# Altium schematic sheet pca10036_sheet1_radio.SchDoc
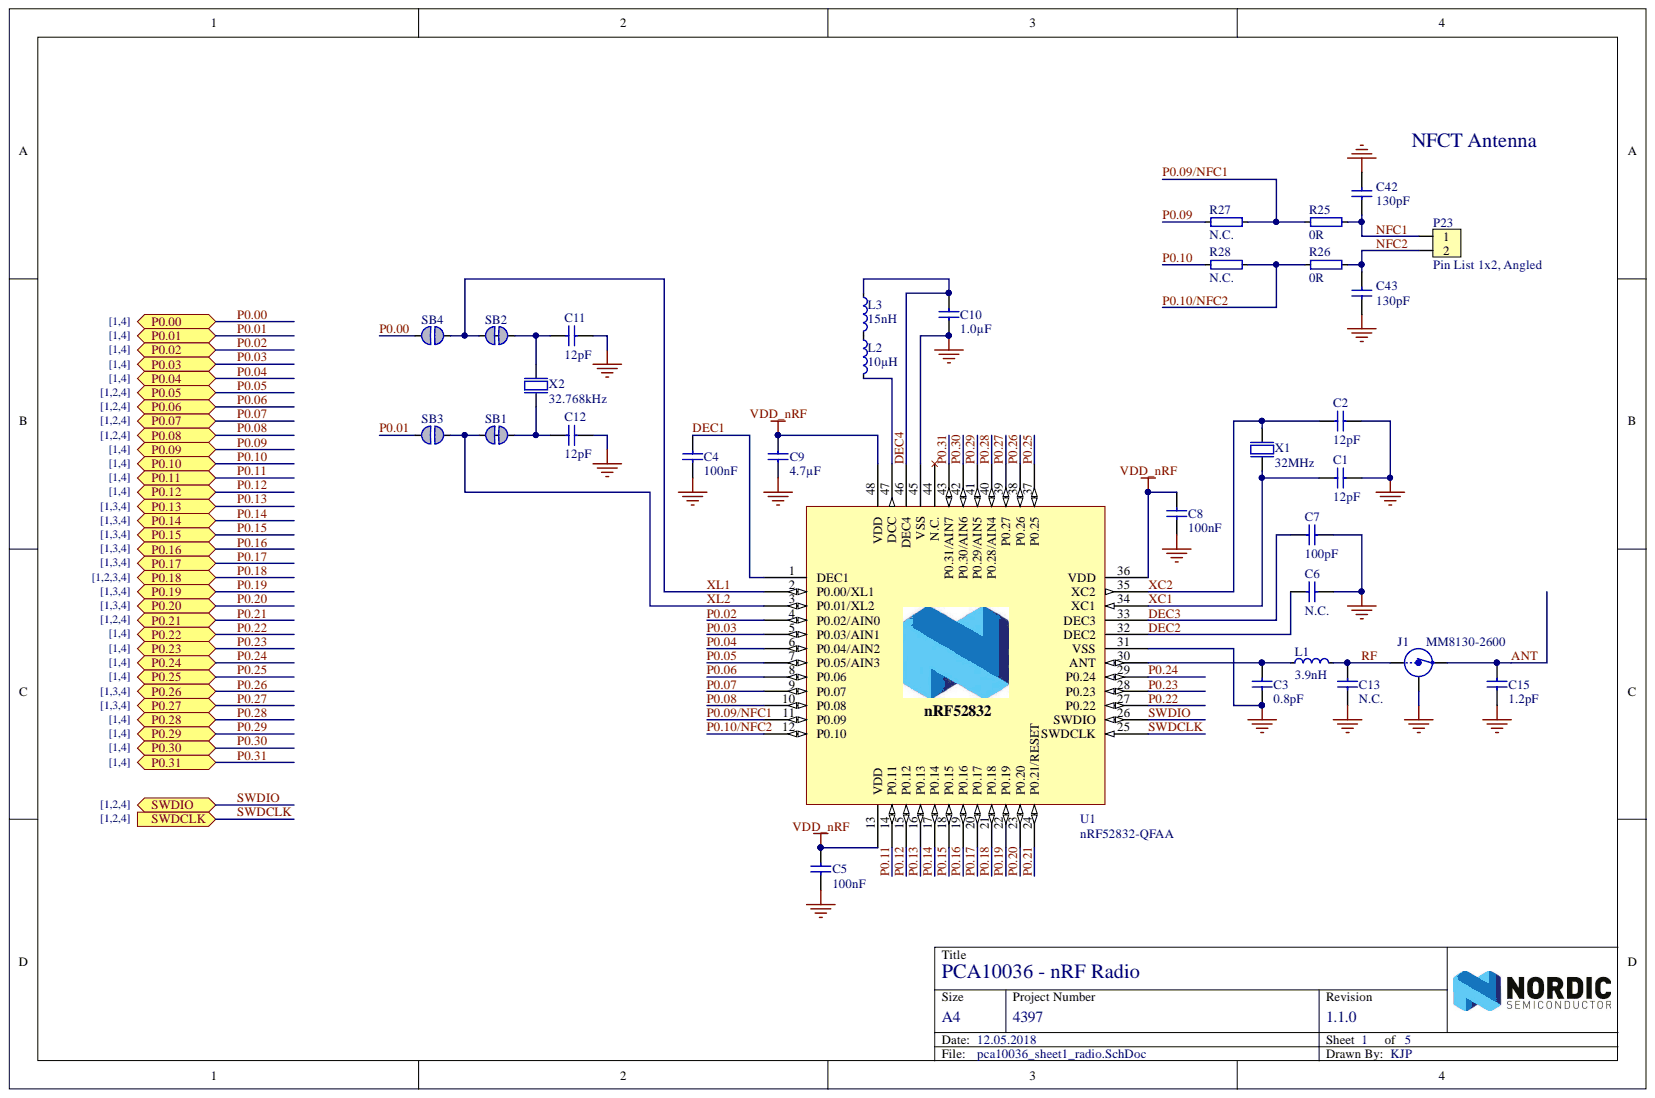
[diagram p0: pca10036_sheet1_radio.SchDoc]

## Parts
C1  12pF  [CAPC1005X04L]
C2  12pF  [CAPC1005X04L]
C3  0.8pF  [CAPC1005X04L]
C4  100nF  [CAPC1005X04L]
C5  100nF  [CAPC1005X04L]
C6  N.C.  [CAPC1005X04L]
C7  100pF  [CAPC1005X04L]
C8  100nF  [CAPC1005X04L]
C9  4.7µF  [CAPC1608X06L]
C10  1.0µF  [CAPC1608X06L]
C11  12pF  [CAPC1005X04L]
C12  12pF  [CAPC1005X04L]
C13  N.C.  [CAPC1005X04L]
C15  1.2pF  [CAPC1005X04L]
C42  130pF  [CAPC1005X04L]
C43  130pF  [CAPC1005X04L]
J1  MM8130-2600  [COAXIAL-SWF]
L1  3.9nH  [INDC1005X04L]
L2  10µH  [INDC1608X06L]
L3  15nH  [INDC1005X04L]
P23  Pin List 1x2, Angled  [LIST_ANG_1x2-SMD-2.00MM]
R25  0R  [RESC1005X04L]
R26  0R  [RESC1005X04L]
R27  N.C.  [RESC1005X04L]
R28  N.C.  [RESC1005X04L]
SB1  Solderbridge  [Solderbridge_Shorted]  (value not drawn)
SB2  Solderbridge  [Solderbridge_Shorted]  (value not drawn)
SB3  Solderbridge  [Solderbridge]  (value not drawn)  (no BOM)
SB4  Solderbridge  [Solderbridge]  (value not drawn)  (no BOM)
U1  nRF52832-QFAA  [QFN40P600X600X90-48N]
X1  32MHz  [BT-XTAL_2016]
X2  32.768kHz  [XTAL_3215]

## Ports
P0.00, P0.01, P0.02, P0.03, P0.04, P0.05, P0.06, P0.07, P0.08, P0.09, P0.10, P0.11, P0.12, P0.13, P0.14, P0.15, P0.16, P0.17, P0.18, P0.19, P0.20, P0.21, P0.22, P0.23, P0.24, P0.25, P0.26, P0.27, P0.28, P0.29, P0.30, P0.31, SWDCLK, SWDIO

## Nets
GND: C1.2 C2.2 C3.1 C4.2 C5.2 C6.2 C7.2 C8.2 C9.2 C10.1 C11.1 C12.1 C13.2 C15.2 C42.2 C43.1 J1.2 U1.31(VSS) U1.45(VSS) U1.49 X1.2 X1.4
VDD_nRF: C5.1 C8.1 C9.1 U1.13(VDD) U1.36(VDD) U1.48(VDD)
ANT: C15.1 J1.3
DEC1: C4.1 U1.1(DEC1)
DEC2: C6.1 U1.32(DEC2)
DEC3: C7.1 U1.33(DEC3)
DEC4: C10.2 L3.1 U1.46(DEC4)
NFC1: C42.1 P23.1 R25.1
NFC2: C43.2 P23.2 R26.1
P0.00: SB4.2 ; port P0.00
P0.01: SB3.2 ; port P0.01
P0.02: U1.4(P0.02/AIN0) ; port P0.02
P0.03: U1.5(P0.03/AIN1) ; port P0.03
P0.04: U1.6(P0.04/AIN2) ; port P0.04
P0.05: U1.7(P0.05/AIN3) ; port P0.05
P0.06: U1.8(P0.06) ; port P0.06
P0.07: U1.9(P0.07) ; port P0.07
P0.08: U1.10(P0.08) ; port P0.08
P0.09: R27.1 ; port P0.09
P0.09/NFC1: R25.2 R27.2 U1.11(P0.09)
P0.10: R28.1 ; port P0.10
P0.10/NFC2: R26.2 R28.2 U1.12(P0.10)
P0.11: U1.14(P0.11) ; port P0.11
P0.12: U1.15(P0.12) ; port P0.12
P0.13: U1.16(P0.13) ; port P0.13
P0.14: U1.17(P0.14) ; port P0.14
P0.15: U1.18(P0.15) ; port P0.15
P0.16: U1.19(P0.16) ; port P0.16
P0.17: U1.20(P0.17) ; port P0.17
P0.18: U1.21(P0.18) ; port P0.18
P0.19: U1.22(P0.19) ; port P0.19
P0.20: U1.23(P0.20) ; port P0.20
P0.21: U1.24(P0.21/RESET) ; port P0.21
P0.22: U1.27(P0.22) ; port P0.22
P0.23: U1.28(P0.23) ; port P0.23
P0.24: U1.29(P0.24) ; port P0.24
P0.25: U1.37(P0.25) ; port P0.25
P0.26: U1.38(P0.26) ; port P0.26
P0.27: U1.39(P0.27) ; port P0.27
P0.28: U1.40(P0.28/AIN4) ; port P0.28
P0.29: U1.41(P0.29/AIN5) ; port P0.29
P0.30: U1.42(P0.30/AIN6) ; port P0.30
P0.31: U1.43(P0.31/AIN7) ; port P0.31
RF: C13.1 J1.1 L1.2
SWDCLK: U1.25(SWDCLK) ; port SWDCLK
SWDIO: U1.26(SWDIO) ; port SWDIO
XC1: C1.1 U1.34(XC1) X1.1
XC2: C2.1 U1.35(XC2) X1.3
XL1: SB2.2 SB4.1 U1.2(P0.00/XL1)
XL2: SB1.2 SB3.1 U1.3(P0.01/XL2)
NetC3_2: C3.2 L1.1 U1.30(ANT)
NetC11_2: C11.2 SB2.1 X2.1
NetC12_2: C12.2 SB1.1 X2.2
NetL2_1: L2.1 L3.2
NetL2_2: L2.2 U1.47(DCC)

Not connected: U1 44(N.C.)

## Library data of the parts
C1 C2 C11 C12 = C0402-12p: Capacitor, NP0, ±2%; Artikkelnr Noca: 20029159; Artikkelnr Simpro: N.A.; Database Table Name: Capacitors$; Note: 50V; Component Kind: Standard; Footprint Doc: 0402; LatestRevisionDate: 2011-05-11; Library Name: Nordic Passive DB.DbLib; Part Number: C0402-12p; Pin Count: 2; Published: 2009-05-08; Value: 12pF; Publisher: Nordic Semiconductor
C3 = C0402-0.8p: Capacitor, NP0, ±5%; Artikkelnr Noca: 20029147; Artikkelnr Simpro: N.A.; Database Table Name: Capacitors$; Note: 50V; Component Kind: Standard; Footprint Doc: 0402; LatestRevisionDate: 2012-11-21; Library Name: Nordic Passive DB.DbLib; Part Number: C0402-0.8p; Pin Count: 2; Published: 2009-05-08; Value: 0.8pF; Publisher: Nordic Semiconductor
C4 C5 C8 = C0402-100n: Capacitor, X7R, ±10%; Artikkelnr Noca: 20039200; Artikkelnr Simpro: N.A.; Database Table Name: Capacitors$; Note: 16V; Component Kind: Standard; Footprint Doc: 0402; LatestRevisionDate: 2009-11-23; Library Name: Nordic Passive DB.DbLib; Part Number: C0402-100n; Pin Count: 2; Published: 2009-05-08; Value: 100nF; Publisher: Nordic Semiconductor
C6 C13 = C0402-N.C.: Not mounted; Artikkelnr Noca: N.A.; Database Table Name: Capacitors$; Note: Chip Cap; Artikkelnr Simpro: N.A.; Component Kind: Standard; Footprint Doc: 0402; LatestRevisionDate: 2009-05-08; Library Name: Nordic Passive DB.DbLib; Part Number: C0402-N.C.; Pin Count: 2; Published: 2009-05-08; Value: N.C.; Publisher: Nordic Semiconductor
C7 = C0402-100p: Capacitor, NP0, ±5%; Artikkelnr Noca: 20029135; Artikkelnr Simpro: N.A.; Database Table Name: Capacitors$; Note: 50V; Component Kind: Standard; Footprint Doc: 0402; LatestRevisionDate: 2009-05-08; Library Name: Nordic Passive DB.DbLib; Part Number: C0402-100p; Pin Count: 2; Published: 2009-05-08; Value: 100pF; Publisher: Nordic Semiconductor
C9 = C0603-4µ7: Capacitor, X5R, ±10%; Artikkelnr Noca: 20069060; Artikkelnr Simpro: N.A.; Database Table Name: Capacitors$; Note: 6.3V; Component Kind: Standard; Footprint Doc: 0603; LatestRevisionDate: 2009-11-23; Library Name: Nordic Passive DB.DbLib; Part Number: C0603-4µ7; Pin Count: 2; Published: 2009-05-08; Value: 4.7µF; Publisher: Nordic Semiconductor
C10 = C0603-1µ0: Capacitor, X7R, ±10%; Artikkelnr Noca: 20039335; Artikkelnr Simpro: N.A.; Database Table Name: Capacitors$; Note: 16V; Component Kind: Standard; Footprint Doc: 0603; LatestRevisionDate: 2013-06-05; Library Name: Nordic Passive DB.DbLib; Part Number: C0603-1µ0; Pin Count: 2; Published: 2009-05-08; Value: 1.0µF; Publisher: Nordic Semiconductor
C15 = C0402-1.2p: Capacitor, NP0, ±0.1pF; Artikkelnr Noca: 20029157; Artikkelnr Simpro: N.A.; Database Table Name: Capacitors$; Note: 50V; Component Kind: Standard; Footprint Doc: 0402; LatestRevisionDate: 2011-05-11; Library Name: Nordic Passive DB.DbLib; Part Number: C0402-1.2p; Pin Count: 2; Published: 2009-05-08; Value: 1.2pF; Publisher: Nordic Semiconductor
C42 C43 = C0402-130p: Chip Cap 0402; Artikkelnr Noca: N.A.; Database Table Name: Capacitors$; Note: Chip Cap 1%; Artikkelnr Simpro: N.A.; Component Kind: Standard; Footprint Doc: 0402; LatestRevisionDate: 2015-04-24; Library Name: Nordic Passive DB.DbLib; Part Number: C0402-130p; Pin Count: 2; Published: 2015-04-24; Value: 130pF; Publisher: Nordic Semiconductor
J1 = MM8130-2600: Coaxial Connector with Switch; 2nd source part number: 81-MM8130-2600RA2; Manufacturer: Murata; Artikkelnr Noca: 55029011; Artikkelnr Simpro: N.A.; Database Table Name: Connectors$; 2nd source Supplier: Mouser; Component Kind: Standard; Datasheet: http://www.murata.com/products/catalog/pdf/o33e.pdf; Footprint Doc: COAXIAL-SWF; LatestRevisionDate: 2014-04-24; Library Name: Nordic Passive DB.DbLib; Manufacturer Part Number: MM8130-2600; Part Number: MM8130-2600; Pin Count: 3; Published: 2014-04-24; Supplier: Digi-Key; Supplier part number: 490-4981-1-ND; Value: MM8130-2600; Publisher: Nordic Semiconductor
L1 = L0402-3n9: Inductor, 170mA, ±0.1nH; Manufacturer: Murata; Manufacturer Part Number: LQP15MN3N9B02D; Artikkelnr Noca: 33029151; Artikkelnr Simpro: N.A.; Database Table Name: Inductors$; Note: High frequency chip inductor ±5%; Component Kind: Standard; Datasheet: http://search.murata.co.jp/Ceramy/image/img/PDF/ENG/L0075S0084LQP15M.pdf; Footprint Doc: 0402; LatestRevisionDate: 2012-09-19; Library Name: Nordic Passive DB.DbLib; Part Number: L0402-3n9; Pin Count: 2; Published: 2009-05-08; Supplier: Digi-Key; Supplier part number: 490-1131-1-ND; Value: 3.9nH; Publisher: Nordic Semiconductor
L2 = L0603-10µ: Inductor, 80mA, ±10%; 2nd source part number: 178-6105; Manufacturer: Taiyo Yuden; Manufacturer Part Number: LBMF1608T100K; Artikkelnr Noca: 33029314; Artikkelnr Simpro: N.A.; Database Table Name: Inductors$; Note: LBMF1608T100K 10µH 80mA 0603; 2nd source Supplier: Farnell; Component Kind: Standard; Datasheet: http://www.yuden.co.jp/ut/product/pdf/elb2_e.pdf; Footprint Doc: 0603; LatestRevisionDate: 2012-09-19; Library Name: Nordic Passive DB.DbLib; Part Number: L0603-10µ; Pin Count: 2; Published: 2010-02-24; Supplier: Digi-Key; Supplier part number: 587-1714-1-ND; Value: 10µH; Publisher: Nordic Semiconductor
L3 = L0402-15n: Inductor, 320mA, ±5%; 2nd source part number: 658-1328; Manufacturer: Taiyo Yuden; Manufacturer Part Number: HK100515NJ-T; Artikkelnr Noca: 33029323; Artikkelnr Simpro: N.A.; Database Table Name: Inductors$; Note: High frequency chip inductor ±10%; 2nd source Supplier: Farnell; Component Kind: Standard; Datasheet: http://www.yuden.co.jp/productdata/catalog/en/mlci04_e.pdf; Footprint Doc: 0402; LatestRevisionDate: 2012-09-19; Library Name: Nordic Passive DB.DbLib; Part Number: L0402-15n; Pin Count: 2; Published: 2010-07-12; Supplier: Digi-Key; Supplier part number: 587-1521-1-ND; Value: 15nH; Publisher: Nordic Semiconductor
P23 = Pin List Ang 1x2 SMD, 2.00mm: Pin List 1x2, 2.00mm, Right Angled, SMD; 2nd source part number: MMT-102-01-S-SH-ND; Artikkelnr Noca: N.A.; Database Table Name: Connectors$; 2nd source Supplier: Digi-Key; Artikkelnr Simpro: N.A.; Component Kind: Standard; Footprint Doc: LIST_ANG_1x2-SMD-2.00MM; LatestRevisionDate: 2015-01-19; Library Name: Nordic Passive DB.DbLib; Part Number: Pin List Ang 1x2 SMD, 2.00mm; Pin Count: 2; Published: 2015-01-19; Supplier: Samtec; Supplier part number: MMT-102-01-S-SH; Value: Pin List 1x2, Angled; Publisher: Nordic Semiconductor
R25 R26 = R0402-0R: Resistor, ±5%, 0.063W; Artikkelnr Noca: 27049000; Artikkelnr Simpro: N.A.; Database Table Name: Resistors$; Note: Chip Res 5%; Component Kind: Standard; Footprint Doc: 0402; LatestRevisionDate: 2009-11-23; Library Name: Nordic Passive DB.DbLib; Part Number: R0402-0R; Pin Count: 2; Published: 2009-05-08; Supplier: Farnell; Supplier part number: 146-9661; Value: 0R; Publisher: Nordic Semiconductor
R27 R28 = R0402-N.C.: Not mounted; Artikkelnr Noca: N.A.; Database Table Name: Resistors$; Note: Chip Res; Artikkelnr Simpro: N.A.; Component Kind: Standard; Footprint Doc: 0402; LatestRevisionDate: 2009-05-08; Library Name: Nordic Passive DB.DbLib; Part Number: R0402-N.C.; Pin Count: 2; Published: 2009-05-08; Value: N.C.; Publisher: Nordic Semiconductor
SB1 SB2 = Solderbridge Shorted: Shorted Solderbridge; Artikkelnr Noca: N.A.; Database Table Name: Connectors$; Artikkelnr Simpro: N.A.; Component Kind: Net Tie; Footprint Doc: Solderbridge_Shorted; LatestRevisionDate: 2012-08-07; Library Name: Nordic Passive DB.DbLib; Part Number: Solderbridge Shorted; Pin Count: 2; Published: 2012-08-07; Value: Solderbridge; Publisher: Nordic Semiconductor
SB3 SB4 = Solderbridge: Artikkelnr Noca: N.A.; Database Table Name: Connectors$; Artikkelnr Simpro: N.A.; Description: Solderbridge; Footprint Doc: Solderbridge; LatestRevisionDate: 2009-12-14; Library Name: Nordic Passive DB.DbLib; Part Number: Solderbridge; Pin Count: 2; Published: 2009-12-14; Value: Solderbridge; Publisher: Nordic Semiconductor
U1 = nRF52832-QFAA: Multi-protocol Bluetooth Low Energy and 2.4GHz proprietary system-on-chip; Manufacturer: Nordic Semiconductor; Artikkelnr Noca: N.A.; Database Table Name: nRF Devices$; Artikkelnr Simpro: N.A.; Component Kind: Standard; Footprint Doc: QFN-48; LatestRevisionDate: 2014-11-26; Library Name: Nordic Active DB.DbLib; Manufacturer Part Number: nRF52832-QFAA; Part Number: nRF52832-QFAA; Pin Count: 48; Published: 2014-11-26; Supplier: Nordic Semiconductor; Value: nRF52832-QFAA; Publisher: Nordic Semiconductor
X1 = XTAL_SMD2016_32MHz_8pF_Epson: XTAL SMD 2016, 32MHz, Cl=8pF, Tol: ±10ppm, Stab: ±10ppm; Manufacturer: Epson Toyocom; Manufacturer Part Number: Q22FA12800025; Artikkelnr Noca: N.A.; Database Table Name: Crystal_Osc$; Note: XTAL SMD 32.000MHz; Artikkelnr Simpro: N.A.; Component Kind: Standard; Datasheet: http://www.epsondevice.com/docs/qd/en/DownloadServlet?id=ID000449; Footprint Doc: XTAL_2016; LatestRevisionDate: 2014-11-27; Library Name: Nordic Discrete DB.DbLib; Part Number: XTAL_SMD2016_32MHz_8pF_Epson; Pin Count: 4; Published: 2012-03-09; Supplier: Farnell; Supplier part number: 171-2806; Value: 32MHz; Publisher: Nordic Semiconductor
X2 = XTAL_FC-135 32.768k: EPSON TOYOCOM - FC-135 32.768KHZ ±20PPM,9.0PF - CRYSTAL, SM, WATCH; 2nd source part number: 127-8037; Artikkelnr Noca: 22019091; Artikkelnr Simpro: N.A.; Database Table Name: Crystal_Osc$; Note: XTAL SMD 32.768kHz, 3215; 2nd source Supplier: Farnell; Component Kind: Standard; Datasheet: http://www.farnell.com/datasheets/57480.pdf; Footprint Doc: XTAL_3215; LatestRevisionDate: 2014-03-27; Library Name: Nordic Discrete DB.DbLib; Manufacturer: Epson Toyocom; Manufacturer Part Number: FC-135 32.768KHZ ±20PPM,9.0PF; Part Number: XTAL_FC-135 32.768k; Pin Count: 2; Published: 2010-10-18; Supplier: Digi-Key; Supplier part number: FC-135 32.7680KA-AC-ND; Value: 32.768kHz; Publisher: Nordic Semiconductor
